# Altium schematic sheet DEVICE2.SchDoc(DEVICE2)
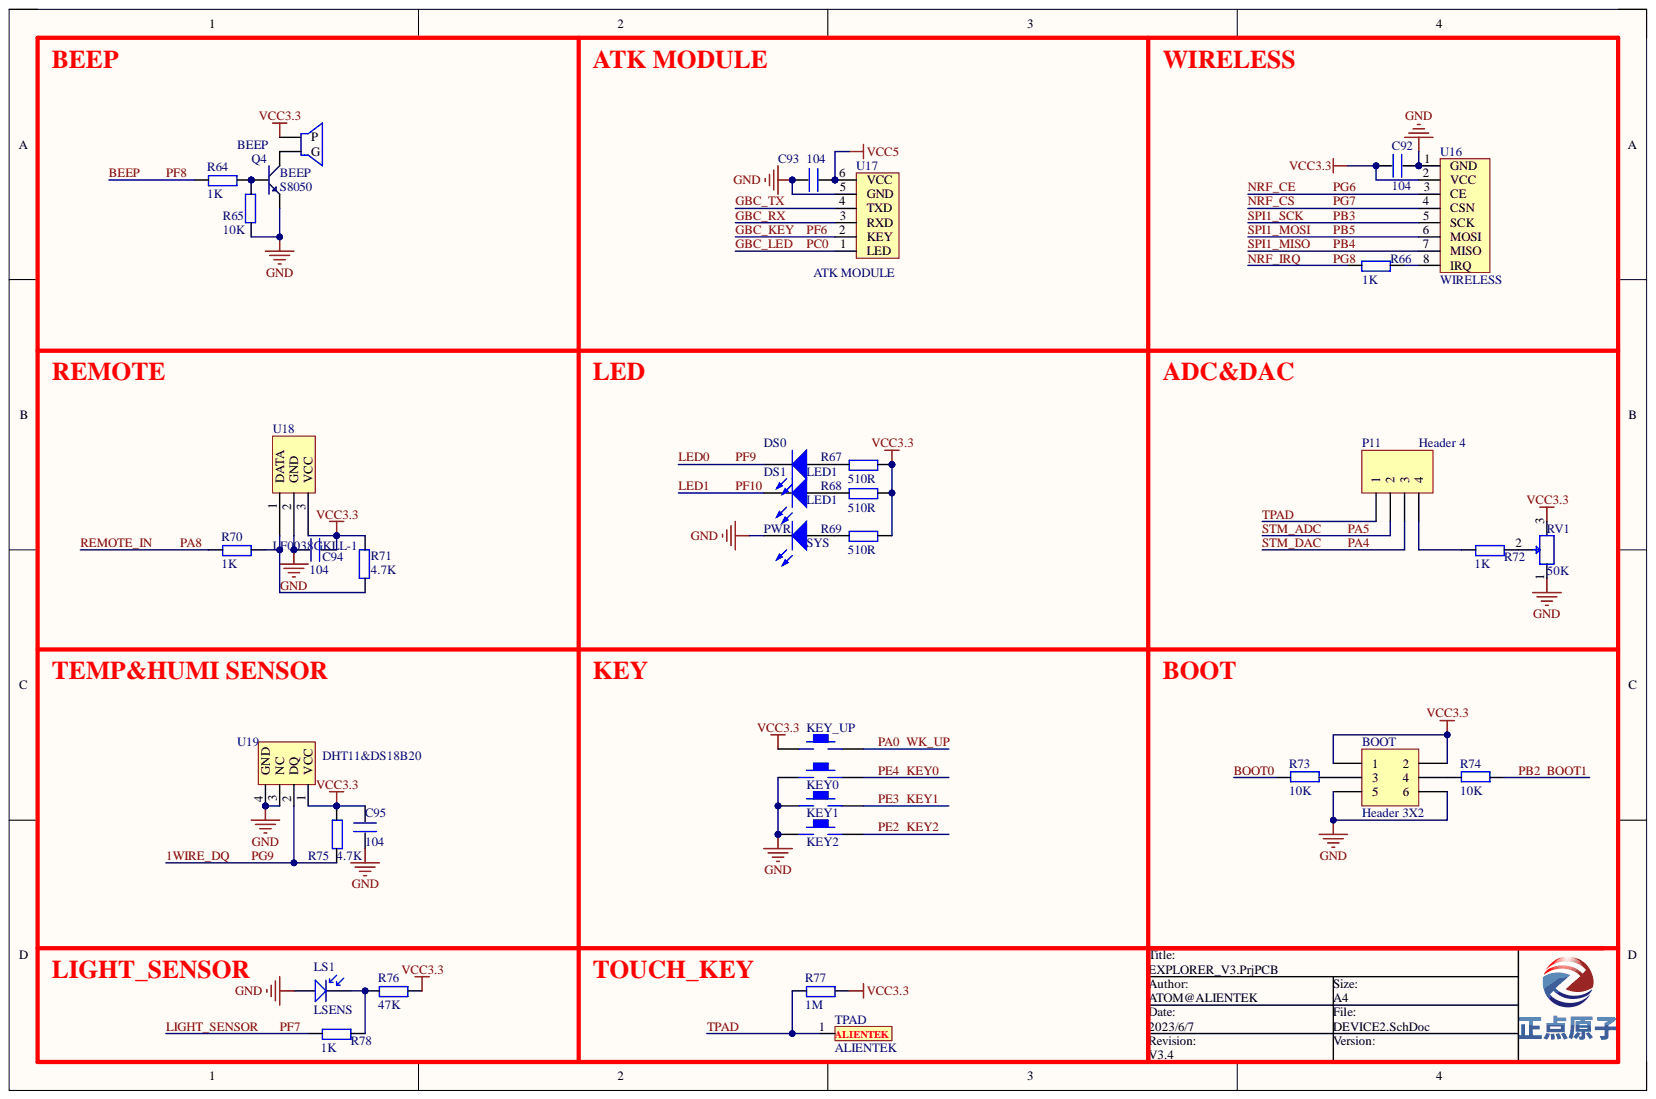
[diagram p0: DEVICE2.SchDoc]

## Parts
BEEP  BEEP  [BELL-5]
BOOT  Header 3X2  [HDR2X3]
C92  104  [0402]
C93  104  [0402]
C94  104  [0402]
C95  104  [0402]
DS0  LED1  [SMDLED]
DS1  LED1  [SMDLED]
KEY0  KEY_M  [KEY_M]  (value not drawn)
KEY1  KEY_M  [KEY_M]  (value not drawn)
KEY2  KEY_M  [KEY_M]  (value not drawn)
KEY_UP  KEY_M  [KEY_M]  (value not drawn)
LS1  LSENS  [SMDLED]
P11  Header 4  [HDR1X4]
PWR  SYS  [SMDLED]
Q4  S8050  [SOT-23R]
R64  1K  [0402]
R65  10K  [0402]
R66  1K  [0402]
R67  510R  [0402]
R68  510R  [0402]
R69  510R  [0402]
R70  1K  [0402]
R71  4.7K  [0402]
R72  1K  [0402]
R73  10K  [0402]
R74  10K  [0402]
R75  4.7K  [0402]
R76  47K  [0402]
R77  1M  [0402]
R78  1K  [0402]
RV1  50K  [RV]
TPAD  ALIENTEK  [ATK_TPAD]
U16  WIRELESS  [NRF24L01]
U17  ATK MODULE  [HEAD6]
U18  LF0038GKLL-1  [IR-LF0038GKLL-1]
U19  DHT11&DS18B20  [DHT11]

## Nets
GND: BOOT.5 BOOT.6 C92.1 C93.2 C94.2 C95.2 KEY0.1 KEY1.1 KEY2.1 LS1.1 PWR.2 Q4.1 R65.2 RV1.1 U16.1(GND) U17.5(GND) U18.2 U19.3(NC) U19.4(GND)
VCC3.3: BEEP.1 BOOT.1 BOOT.2 C92.2 C94.1 C95.1 KEY_UP.1 R67.2 R68.2 R69.2 R71.1 R75.2 R76.2 R77.2 RV1.3 U16.2(VCC) U18.3 U19.1(VCC)
VCC5: C93.1 U17.6(VCC)
1WIRE_DQ: R75.1 U19.2(DQ)
BEEP: R64.1
BOOT0: R73.2
BOOT1: R74.1
GBC_KEY: U17.2(KEY)
GBC_LED: U17.1(LED)
GBC_RX: U17.3(RXD)
GBC_TX: U17.4(TXD)
KEY0: KEY0.2
KEY1: KEY1.2
KEY2: KEY2.2
LED0: DS0.2
LED1: DS1.2
LIGHT_SENSOR: R78.1
NRF_CE: U16.3(CE)
NRF_CS: U16.4(CSN)
NRF_IRQ: R66.1
PA0: KEY_UP.2
PA4: P11.3
PA5: P11.2
PA8: R70.1
PB3: U16.5(SCK)
PB4: U16.7(MISO)
PB5: U16.6(MOSI)
TPAD: P11.1 R77.1 TPAD.1
NetBEEP_2: BEEP.2 Q4.3
NetBOOT_3: BOOT.3 R73.1
NetBOOT_4: BOOT.4 R74.2
NetDS0_1: DS0.1 R67.1
NetDS1_1: DS1.1 R68.1
NetLS1_2: LS1.2 R76.1 R78.2
NetP11_4: P11.4 R72.1
NetPWR_1: PWR.1 R69.1
NetQ4_2: Q4.2 R64.2 R65.1
NetR66_2: R66.2 U16.8(IRQ)
NetR70_2: R70.2 R71.2 U18.1
NetR72_2: R72.2 RV1.2

## Library data of the parts
BOOT = Header 3X2: Header, 3-Pin, Dual row; Component Kind: Standard; LatestRevisionDate: 17-Jul-2002; LatestRevisionNote: Re-released for DXP Platform.; Library Name: Miscellaneous Connectors.IntLib; Publisher: Altium Limited
C92-C95 = C: Component Kind: Standard; Library Name: MYCOMLIB.IntLib
DS0 DS1 PWR = LED2: Typical RED, GREEN, YELLOW, AMBER GaAs LED; Component Kind: Standard; LatestRevisionDate: 17-Jul-2002; LatestRevisionNote: Re-released for DXP Platform.; Library Name: Miscellaneous Devices.IntLib; PackageDocument: Feb-2002; PackageReference: 3.2X1.6X1.1; Published: 8-Jun-2000; Simulation: LED2; Publisher: Altium Limited
LS1 = LSENS: LIGHT SENS; Component Kind: Standard; Library Name: ALIENTEK_COMPONENT.IntLib
P11 = Header 4: Header, 4-Pin; Component Kind: Standard; LatestRevisionDate: 17-Jul-2002; LatestRevisionNote: Re-released for DXP Platform.; Library Name: Miscellaneous Connectors.IntLib; Publisher: Altium Limited
Q4 = NPN: 8050/BCW846/BCW847; Component Kind: Standard; Library Name: MYCOMLIB.IntLib
R64-R78 = R: Component Kind: Standard; Library Name: MYCOMLIB.IntLib
RV1 = RV: Component Kind: Standard; Library Name: ALIENTEK_COMPONENT.IntLib
U16 = NRF24L01: Component Kind: Standard; Library Name: MYCOMLIB.IntLib
U17 = ATK-HC05: Component Kind: Standard; Description: ATK-HC05; Library Name: ALIENTEK_COMPONENT.IntLib
U18 = HS0038: Component Kind: Standard; Library Name: selfmade.SchLib
U19 = DHT11: 数字温湿度传感器; Component Kind: Standard; Library Name: ALIENTEK_COMPONENT.IntLib
BEEP = BEEP: Component Kind: Standard; Library Name: ALIENTEK_COMPONENT.IntLib
KEY0-KEY2 KEY_UP = KEY_M: Component Kind: Standard; Library Name: ALIENTEK_COMPONENT.IntLib
TPAD = ALIENTEK: Component Kind: Standard; Library Name: WARSHIP_STM32_V4.3.SCHLIB
